# Altium schematic sheet FPGA_BANK.SchDoc(FPGA_BANK)
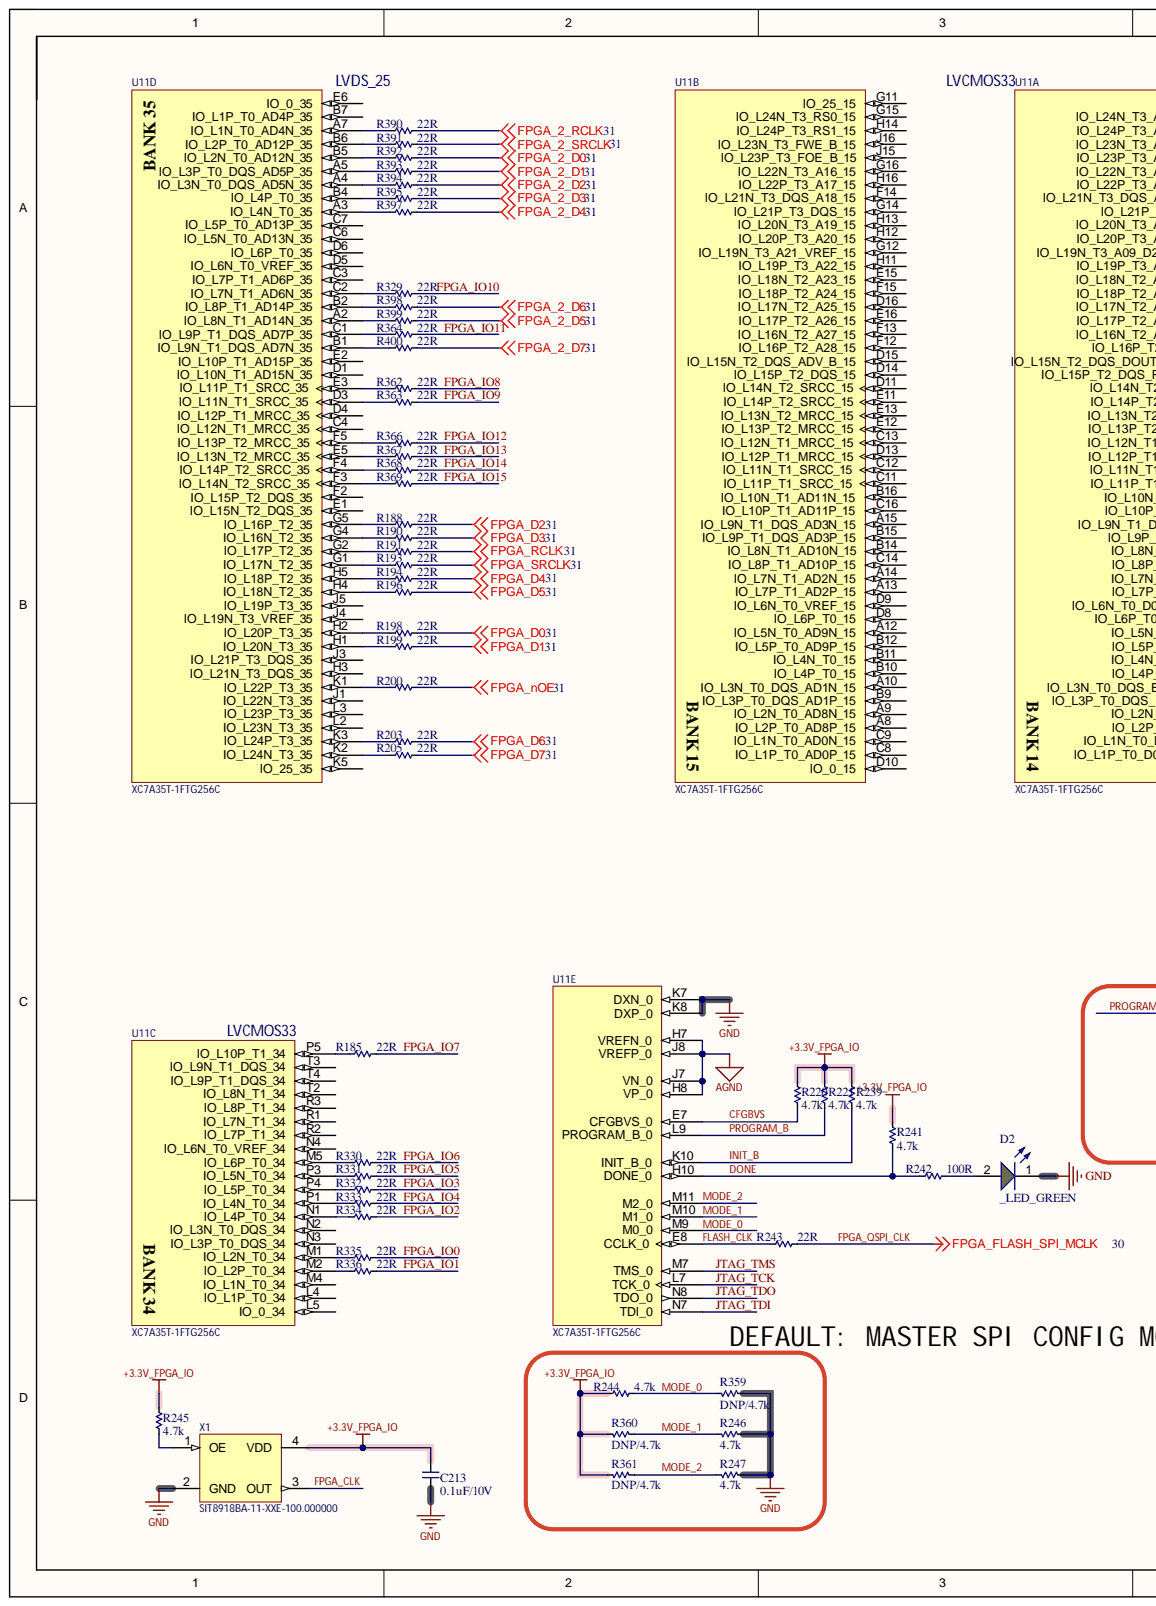
[diagram p0: FPGA_BANK.SchDoc, left]
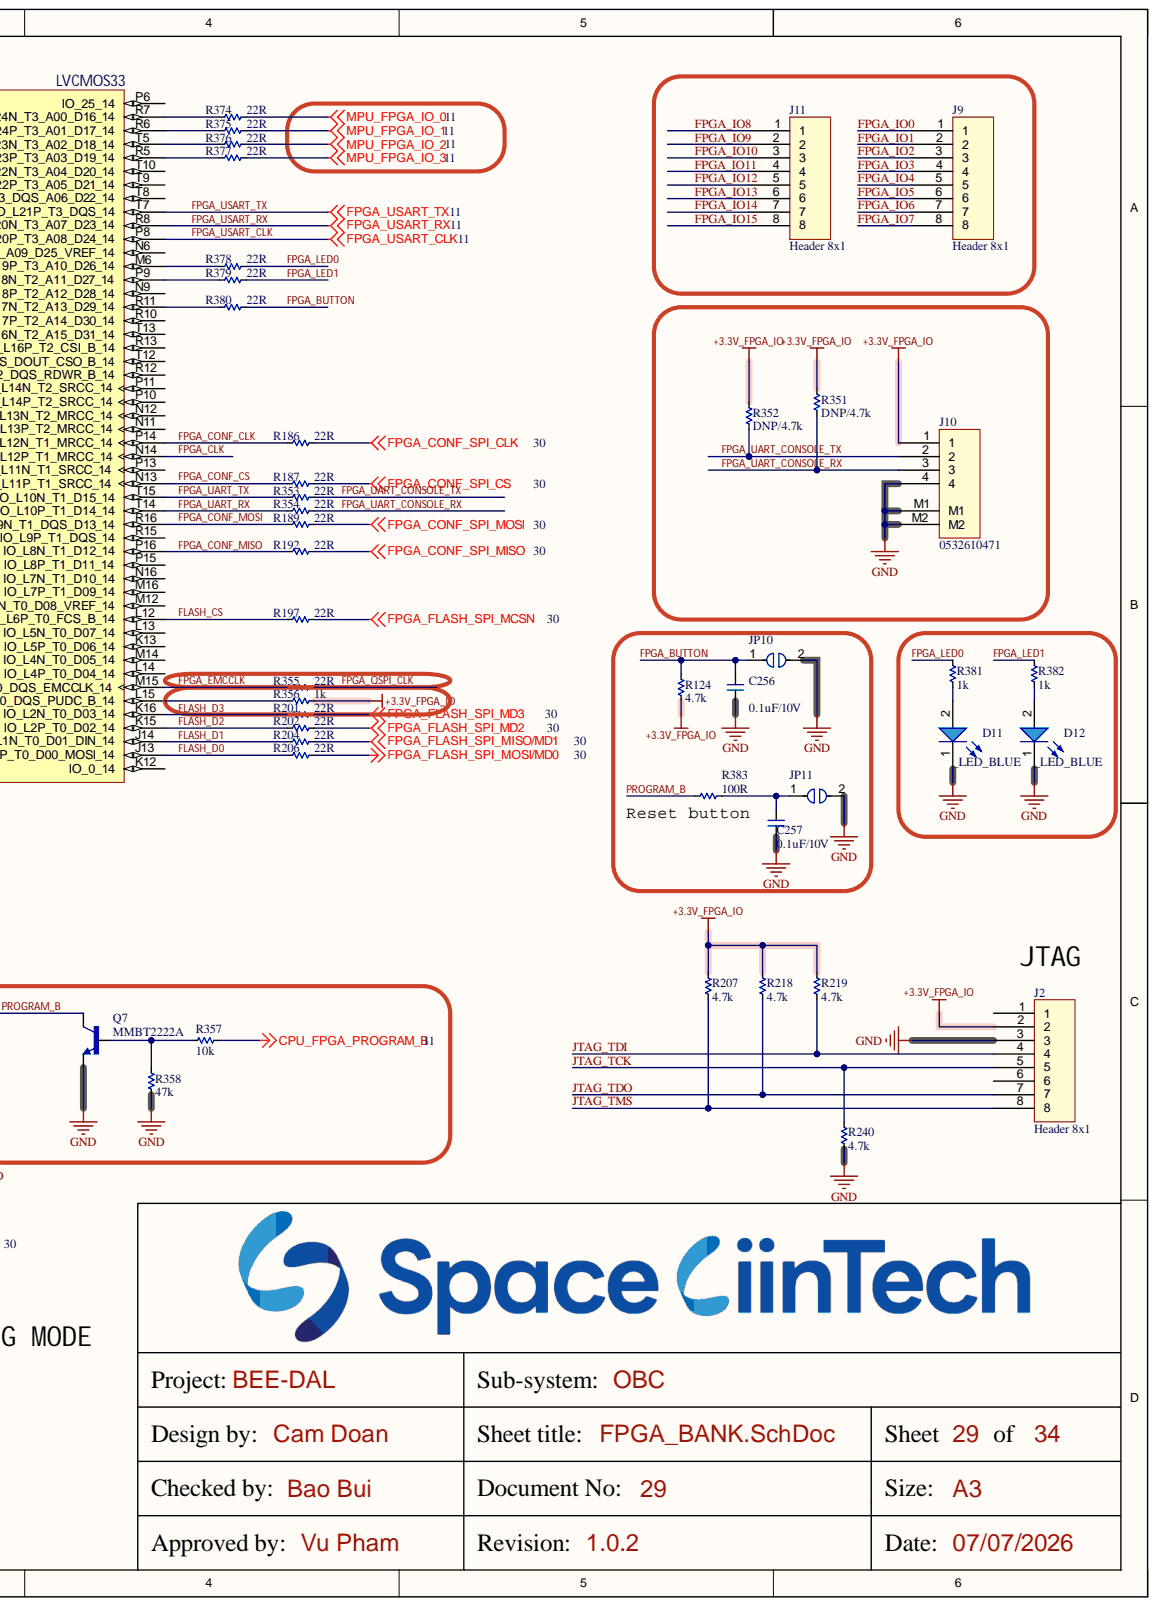
[diagram p1: FPGA_BANK.SchDoc, right]

## Parts
C213  0.1uF/10V  [CAP_C_1005X50_L]
C256  0.1uF/10V  [CAP_C_1005X50_L]
C257  0.1uF/10V  [CAP_C_1005X50_L]
D2  _LED_GREEN  [LED_0603]
D11  _LED_BLUE  [LED_0603]
D12  _LED_BLUE  [LED_0603]
J2  Header 8x1  [Header_254P_8x1]
J9  Header 8x1  [Header_254P_8x1]
J10  0532610471  [MOLEX_0532610471]
J11  Header 8x1  [Header_254P_8x1]
JP10  (no value)  [JUMPER_1608]
JP11  (no value)  [JUMPER_1608]
Q7  MMBT2222A  [SOT95P240X120-3N]
R124  4.7k  [RES_C_1005x30_L]
R185  22R  [RES_C_1005x30_L]
R186  22R  [RES_C_1005x30_L]
R187  22R  [RES_C_1005x30_L]
R188  22R  [RES_C_1005x30_L]
R189  22R  [RES_C_1005x30_L]
R190  22R  [RES_C_1005x30_L]
R191  22R  [RES_C_1005x30_L]
R192  22R  [RES_C_1005x30_L]
R193  22R  [RES_C_1005x30_L]
R194  22R  [RES_C_1005x30_L]
R196  22R  [RES_C_1005x30_L]
R197  22R  [RES_C_1005x30_L]
R198  22R  [RES_C_1005x30_L]
R199  22R  [RES_C_1005x30_L]
R200  22R  [RES_C_1005x30_L]
R201  22R  [RES_C_1005x30_L]
R202  22R  [RES_C_1005x30_L]
R203  22R  [RES_C_1005x30_L]
R204  22R  [RES_C_1005x30_L]
R205  22R  [RES_C_1005x30_L]
R206  22R  [RES_C_1005x30_L]
R207  4.7k  [RES_C_1005x30_L]
R218  4.7k  [RES_C_1005x30_L]
R219  4.7k  [RES_C_1005x30_L]
R220  4.7k  [RES_C_1005x30_L]
R221  4.7k  [RES_C_1005x30_L]
R239  4.7k  [RES_C_1005x30_L]
R240  4.7k  [RES_C_1005x30_L]
R241  4.7k  [RES_C_1005x30_L]
R242  100R  [RES_C_1005x30_L]
R243  22R  [RES_C_1005x30_L]
R244  4.7k  [RES_C_1005x30_L]
R245  4.7k  [RES_C_1005x30_L]
R246  4.7k  [RES_C_1005x30_L]
R247  4.7k  [RES_C_1005x30_L]
R329  22R  [RES_C_1005x30_L]
R330  22R  [RES_C_1005x30_L]
R331  22R  [RES_C_1005x30_L]
R332  22R  [RES_C_1005x30_L]
R333  22R  [RES_C_1005x30_L]
R334  22R  [RES_C_1005x30_L]
R335  22R  [RES_C_1005x30_L]
R336  22R  [RES_C_1005x30_L]
R351  DNP/4.7k  [RES_C_1005x30_L]
R352  DNP/4.7k  [RES_C_1005x30_L]
R353  22R  [RES_C_1005x30_L]
R354  22R  [RES_C_1005x30_L]
R355  22R  [RES_C_1005x30_L]
R356  1k  [RES_C_1005x30_L]
R357  10k  [RES_C_1005x30_L]
R358  47k  [RES_C_1005x30_L]
R359  DNP/4.7k  [RES_C_1005x30_L]
R360  DNP/4.7k  [RES_C_1005x30_L]
R361  DNP/4.7k  [RES_C_1005x30_L]
R362  22R  [RES_C_1005x30_L]
R363  22R  [RES_C_1005x30_L]
R364  22R  [RES_C_1005x30_L]
R366  22R  [RES_C_1005x30_L]
R367  22R  [RES_C_1005x30_L]
R368  22R  [RES_C_1005x30_L]
R369  22R  [RES_C_1005x30_L]
R374  22R  [RES_C_1005x30_L]
R375  22R  [RES_C_1005x30_L]
R376  22R  [RES_C_1005x30_L]
R377  22R  [RES_C_1005x30_L]
R378  22R  [RES_C_1005x30_L]
R379  22R  [RES_C_1005x30_L]
R380  22R  [RES_C_1005x30_L]
R381  1k  [RES_C_1005x30_L]
R382  1k  [RES_C_1005x30_L]
R383  100R  [RES_C_1005x30_L]
R390  22R  [RES_C_1005x30_L]
R391  22R  [RES_C_1005x30_L]
R392  22R  [RES_C_1005x30_L]
R393  22R  [RES_C_1005x30_L]
R394  22R  [RES_C_1005x30_L]
R395  22R  [RES_C_1005x30_L]
R397  22R  [RES_C_1005x30_L]
R398  22R  [RES_C_1005x30_L]
R399  22R  [RES_C_1005x30_L]
R400  22R  [RES_C_1005x30_L]
U11  XC7A35T-1FTG256C  [FTG256]  (parts A,B,C,D,E)
X1  SIT8918BA-11-XXE-100.000000  [CRYSTAL_ABM8G]

## Nets
+3.3V_FPGA_IO: C213.2 J2.2 J10.1 R124.1 R207.2 R218.2 R219.2 R220.2 R221.2 R239.2 R241.2 R244.1 R245.2 R351.1 R352.1 R356.2 R360.2 R361.2 X1.4(VDD)
AGND: U11.H7(VREFN_0) U11.H8(VP_0) U11.J7(VN_0) U11.J8(VREFP_0)
CPU_FPGA_PROGRAM_B: R357.1
FPGA_2_D0: R392.2
FPGA_2_D1: R393.2
FPGA_2_D2: R394.2
FPGA_2_D3: R395.2
FPGA_2_D4: R397.2
FPGA_2_D5: R399.2
FPGA_2_D6: R398.2
FPGA_2_D7: R400.2
FPGA_2_RCLK: R390.2
FPGA_2_SRCLK: R391.2
FPGA_CONF_SPI_CLK: R186.2
FPGA_CONF_SPI_CS: R187.2
FPGA_CONF_SPI_MISO: R192.2
FPGA_CONF_SPI_MOSI: R189.2
FPGA_D0: R198.2
FPGA_D1: R199.2
FPGA_D2: R188.2
FPGA_D3: R190.2
FPGA_D4: R194.2
FPGA_D5: R196.2
FPGA_D6: R203.2
FPGA_D7: R205.2
FPGA_FLASH_SPI_MCSN: R197.2
FPGA_FLASH_SPI_MD2: R202.2
FPGA_FLASH_SPI_MD3: R201.2
FPGA_FLASH_SPI_MISO/MD1: R204.2
FPGA_FLASH_SPI_MOSI/MD0: R206.2
FPGA_nOE: R200.2
FPGA_RCLK: R191.2
FPGA_SRCLK: R193.2
GND: C213.1 C256.1 C257.1 D2.1 D11.1 D12.1 J2.3 J10.4 J10.M1 J10.M2 JP10.2 JP11.2 Q7.2 R240.1 R246.1 R247.1 R358.1 R359.1 U11.K7(DXN_0) U11.K8(DXP_0) X1.2(GND)
MPU_FPGA_IO_0: R374.2
MPU_FPGA_IO_1: R375.2
MPU_FPGA_IO_2: R376.2
MPU_FPGA_IO_3: R377.2
CFGBVS: R220.1 U11.E7(CFGBVS_0)
DONE: R241.1 R242.1 U11.H10(DONE_0)
FLASH_CLK: R243.1 U11.E8(CCLK_0)
FLASH_CS: R197.1 U11.L12(IO_L6P_T0_FCS_B_14)
FLASH_D0: R206.1 U11.J13(IO_L1P_T0_D00_MOSI_14)
FLASH_D1: R204.1 U11.J14(IO_L1N_T0_D01_DIN_14)
FLASH_D2: R202.1 U11.K15(IO_L2P_T0_D02_14)
FLASH_D3: R201.1 U11.K16(IO_L2N_T0_D03_14)
FPGA_BUTTON: C256.2 JP10.1 R124.2 R380.2
FPGA_CLK: U11.N14(IO_L12P_T1_MRCC_14) X1.3(OUT)
FPGA_CONF_CLK: R186.1 U11.P14(IO_L12N_T1_MRCC_14)
FPGA_CONF_CS: R187.1 U11.N13(IO_L11P_T1_SRCC_14)
FPGA_CONF_MISO: R192.1 U11.P16(IO_L8N_T1_D12_14)
FPGA_CONF_MOSI: R189.1 U11.R16(IO_L9N_T1_DQS_D13_14)
FPGA_EMCCLK: R355.1 U11.M15(IO_L3N_T0_DQS_EMCCLK_14)
FPGA_IO0: J9.1 R335.2
FPGA_IO1: J9.2 R336.2
FPGA_IO2: J9.3 R334.2
FPGA_IO3: J9.4 R332.2
FPGA_IO4: J9.5 R333.2
FPGA_IO5: J9.6 R331.2
FPGA_IO6: J9.7 R330.2
FPGA_IO7: J9.8 R185.2
FPGA_IO8: J11.1 R362.2
FPGA_IO9: J11.2 R363.2
FPGA_IO10: J11.3 R329.2
FPGA_IO11: J11.4 R364.2
FPGA_IO12: J11.5 R366.2
FPGA_IO13: J11.6 R367.2
FPGA_IO14: J11.7 R368.2
FPGA_IO15: J11.8 R369.2
FPGA_LED0: R378.2 R381.2
FPGA_LED1: R379.2 R382.2
FPGA_QSPI_CLK: R243.2 R355.2
FPGA_UART_CONSOLE_RX: J10.3 R351.2 R354.2
FPGA_UART_CONSOLE_TX: J10.2 R352.2 R353.2
FPGA_UART_RX: R354.1 U11.T14(IO_L10P_T1_D14_14)
FPGA_UART_TX: R353.1 U11.T15(IO_L10N_T1_D15_14)
FPGA_USART_CLK: U11.P8(IO_L20P_T3_A08_D24_14)
FPGA_USART_RX: U11.R8(IO_L20N_T3_A07_D23_14)
FPGA_USART_TX: U11.T7(IO_L21P_T3_DQS_14)
INIT_B: R239.1 U11.K10(INIT_B_0)
JTAG_TCK: J2.5 R240.2 U11.L7(TCK_0)
JTAG_TDI: J2.4 R219.1 U11.N7(TDI_0)
JTAG_TDO: J2.7 R218.1 U11.N8(TDO_0)
JTAG_TMS: J2.8 R207.1 U11.M7(TMS_0)
MODE_0: R244.2 R359.2 U11.M9(M0_0)
MODE_1: R246.2 R360.1 U11.M10(M1_0)
MODE_2: R247.2 R361.1 U11.M11(M2_0)
PROGRAM_B: Q7.3 R221.1 R383.1 U11.L9(PROGRAM_B_0)
NetC257_2: C257.2 JP11.1 R383.2
NetD2_2: D2.2 R242.2
NetD11_2: D11.2 R381.1
NetD12_2: D12.2 R382.1
NetQ7_1: Q7.1 R357.2 R358.2
NetR185_1: R185.1 U11.P5(IO_L10P_T1_34)
NetR188_1: R188.1 U11.G5(IO_L16P_T2_35)
NetR190_1: R190.1 U11.G4(IO_L16N_T2_35)
NetR191_1: R191.1 U11.G2(IO_L17P_T2_35)
NetR193_1: R193.1 U11.G1(IO_L17N_T2_35)
NetR194_1: R194.1 U11.H5(IO_L18P_T2_35)
NetR196_1: R196.1 U11.H4(IO_L18N_T2_35)
NetR198_1: R198.1 U11.H2(IO_L20P_T3_35)
NetR199_1: R199.1 U11.H1(IO_L20N_T3_35)
NetR200_1: R200.1 U11.K1(IO_L22P_T3_35)
NetR203_1: R203.1 U11.K3(IO_L24P_T3_35)
NetR205_1: R205.1 U11.K2(IO_L24N_T3_35)
NetR245_1: R245.1 X1.1(OE)
NetR329_1: R329.1 U11.C2(IO_L7N_T1_AD6N_35)
NetR330_1: R330.1 U11.M5(IO_L6P_T0_34)
NetR331_1: R331.1 U11.P3(IO_L5N_T0_34)
NetR332_1: R332.1 U11.P4(IO_L5P_T0_34)
NetR333_1: R333.1 U11.P1(IO_L4N_T0_34)
NetR334_1: R334.1 U11.N1(IO_L4P_T0_34)
NetR335_1: R335.1 U11.M1(IO_L2N_T0_34)
NetR336_1: R336.1 U11.M2(IO_L2P_T0_34)
NetR356_1: R356.1 U11.L15(IO_L3P_T0_DQS_PUDC_B_14)
NetR362_1: R362.1 U11.E3(IO_L11P_T1_SRCC_35)
NetR363_1: R363.1 U11.D3(IO_L11N_T1_SRCC_35)
NetR364_1: R364.1 U11.C1(IO_L9P_T1_DQS_AD7P_35)
NetR366_1: R366.1 U11.F5(IO_L13P_T2_MRCC_35)
NetR367_1: R367.1 U11.E5(IO_L13N_T2_MRCC_35)
NetR368_1: R368.1 U11.F4(IO_L14P_T2_SRCC_35)
NetR369_1: R369.1 U11.F3(IO_L14N_T2_SRCC_35)
NetR374_1: R374.1 U11.R7(IO_L24N_T3_A00_D16_14)
NetR375_1: R375.1 U11.R6(IO_L24P_T3_A01_D17_14)
NetR376_1: R376.1 U11.T5(IO_L23N_T3_A02_D18_14)
NetR377_1: R377.1 U11.R5(IO_L23P_T3_A03_D19_14)
NetR378_1: R378.1 U11.M6(IO_L19P_T3_A10_D26_14)
NetR379_1: R379.1 U11.P9(IO_L18N_T2_A11_D27_14)
NetR380_1: R380.1 U11.R11(IO_L17N_T2_A13_D29_14)
NetR390_1: R390.1 U11.A7(IO_L1N_T0_AD4N_35)
NetR391_1: R391.1 U11.B6(IO_L2P_T0_AD12P_35)
NetR392_1: R392.1 U11.B5(IO_L2N_T0_AD12N_35)
NetR393_1: R393.1 U11.A5(IO_L3P_T0_DQS_AD5P_35)
NetR394_1: R394.1 U11.A4(IO_L3N_T0_DQS_AD5N_35)
NetR395_1: R395.1 U11.B4(IO_L4P_T0_35)
NetR397_1: R397.1 U11.A3(IO_L4N_T0_35)
NetR398_1: R398.1 U11.B2(IO_L8P_T1_AD14P_35)
NetR399_1: R399.1 U11.A2(IO_L8N_T1_AD14N_35)
NetR400_1: R400.1 U11.B1(IO_L9N_T1_DQS_AD7N_35)

Not connected: J2 1 6; U11 A8(IO_L2P_T0_AD8P_15) A9(IO_L2N_T0_AD8N_15) A10(IO_L3N_T0_DQS_AD1N_15) A12(IO_L5N_T0_AD9N_15) A13(IO_L7P_T1_AD2P_15) A14(IO_L7N_T1_AD2N_15) A15(IO_L9N_T1_DQS_AD3N_15) B7(IO_L1P_T0_AD4P_35) B9(IO_L3P_T0_DQS_AD1P_15) B10(IO_L4P_T0_15) B11(IO_L4N_T0_15) B12(IO_L5P_T0_AD9P_15) B14(IO_L8N_T1_AD10N_15) B15(IO_L9P_T1_DQS_AD3P_15) B16(IO_L10N_T1_AD11N_15) C3(IO_L7P_T1_AD6P_35) C4(IO_L12N_T1_MRCC_35) C6(IO_L5N_T0_AD13N_35) C7(IO_L5P_T0_AD13P_35) C8(IO_L1P_T0_AD0P_15) C9(IO_L1N_T0_AD0N_15) C11(IO_L11P_T1_SRCC_15) C12(IO_L11N_T1_SRCC_15) C13(IO_L12N_T1_MRCC_15) C14(IO_L8P_T1_AD10P_15) C16(IO_L10P_T1_AD11P_15) D1(IO_L10N_T1_AD15N_35) D4(IO_L12P_T1_MRCC_35) D5(IO_L6N_T0_VREF_35) D6(IO_L6P_T0_35) D8(IO_L6P_T0_15) D9(IO_L6N_T0_VREF_15) D10(IO_0_15) D11(IO_L14N_T2_SRCC_15) D13(IO_L12P_T1_MRCC_15) D14(IO_L15P_T2_DQS_15) D15(IO_L15N_T2_DQS_ADV_B_15) D16(IO_L17N_T2_A25_15) E1(IO_L15N_T2_DQS_35) E2(IO_L10P_T1_AD15P_35) E6(IO_0_35) E11(IO_L14P_T2_SRCC_15) E12(IO_L13P_T2_MRCC_15) E13(IO_L13N_T2_MRCC_15) E15(IO_L18N_T2_A23_15) E16(IO_L17P_T2_A26_15) F2(IO_L15P_T2_DQS_35) F12(IO_L16P_T2_A28_15) F13(IO_L16N_T2_A27_15) F14(IO_L21N_T3_DQS_A18_15) F15(IO_L18P_T2_A24_15) G11(IO_25_15) G12(IO_L19N_T3_A21_VREF_15) G14(IO_L21P_T3_DQS_15) G15(IO_L24N_T3_RS0_15) G16(IO_L22N_T3_A16_15) H3(IO_L21N_T3_DQS_35) H11(IO_L19P_T3_A22_15) H12(IO_L20P_T3_A20_15) H13(IO_L20N_T3_A19_15) H14(IO_L24P_T3_RS1_15) H16(IO_L22P_T3_A17_15) J1(IO_L22N_T3_35) J3(IO_L21P_T3_DQS_35) J4(IO_L19N_T3_VREF_35) J5(IO_L19P_T3_35) J15(IO_L23P_T3_FOE_B_15) J16(IO_L23N_T3_FWE_B_15) K5(IO_25_35) K12(IO_0_14) K13(IO_L5P_T0_D06_14) L2(IO_L23N_T3_35) L3(IO_L23P_T3_35) L4(IO_L1P_T0_34) L5(IO_0_34) L13(IO_L5N_T0_D07_14) L14(IO_L4P_T0_D04_14) M4(IO_L1N_T0_34) M12(IO_L6N_T0_D08_VREF_14) M14(IO_L4N_T0_D05_14) M16(IO_L7P_T1_D09_14) N2(IO_L3N_T0_DQS_34) N3(IO_L3P_T0_DQS_34) N4(IO_L6N_T0_VREF_34) N6(IO_L19N_T3_A09_D25_VREF_14) N9(IO_L18P_T2_A12_D28_14) N11(IO_L13P_T2_MRCC_14) N12(IO_L13N_T2_MRCC_14) N16(IO_L7N_T1_D10_14) P6(IO_25_14) P10(IO_L14P_T2_SRCC_14) P11(IO_L14N_T2_SRCC_14) P13(IO_L11N_T1_SRCC_14) P15(IO_L8P_T1_D11_14) R1(IO_L7N_T1_34) R2(IO_L7P_T1_34) R3(IO_L8P_T1_34) R10(IO_L17P_T2_A14_D30_14) R12(IO_L15P_T2_DQS_RDWR_B_14) R13(IO_L16P_T2_CSI_B_14) R15(IO_L9P_T1_DQS_14) T2(IO_L8N_T1_34) T3(IO_L9N_T1_DQS_34) T4(IO_L9P_T1_DQS_34) T8(IO_L21N_T3_DQS_A06_D22_14) T9(IO_L22P_T3_A05_D21_14) T10(IO_L22N_T3_A04_D20_14) T12(IO_L15N_T2_DQS_DOUT_CSO_B_14) T13(IO_L16N_T2_A15_D31_14)

## Library data of the parts
C213 C256 C257 = _CAP_C_2: CAP; Component Kind: Standard; Library Name: LABLIB.SchLib
D2 = LED_GREEN: LED GREEN CLEAR 0603 SMD; Component Kind: Standard; Library Name: IFBschelib.SchLib
D11 D12 = LED_BLUE: LED BLUE CLEAR 0603 SMD; Component Kind: Standard; Library Name: IFBschelib.SchLib
J10 = Header 4x1_2M: Component Kind: Standard; Library Name: IFBschelib.SchLib
JP10 JP11 = JUMPER_1_1: Component Kind: Standard; Library Name: LABLIB.SchLib
Q7 = BJT_NPN_BEC: MF: Diotec Semiconductor; Check_prices: https://www.snapeda.com/parts/MMBT2222A/Diotec/view-part/?ref=eda; Component Kind: Standard; MP: MMBT2222A; Package: SOT-23 Diotec; SnapEDA_Link: https://www.snapeda.com/parts/MMBT2222A/Diotec/view-part/?ref=snap; Library Name: LABLIB.SchLib
R124 R185-R194 R196-R207 R218-R221 R239-R247 R329-R336 R351-R364 R366-R369 R374-R383 R390-R395 R397-R400 = _RES_B_2: RES; Component Kind: Standard
  R124 R207 R218-R221 R239-R241 R351 R352 R358: Library Name: IFBschelib.SchLib
  R185-R194 R196-R206 R242-R247 R329-R336 R353-R357 R359-R364 R366-R369 R374-R383 R390-R395 R397-R400: Library Name: LABLIB.SchLib
U11 = CMP-0383-00028-1: Artix-7 FPGA, 170 User I/Os, 0 GTP, 256-Ball BGA, Speed Grade 1, Commercial Grade, Pb-Free; Manufacturer: Xilinx; Available User I/O: 170; CMTs: 5; GTP: 0; Logic Cells: 33280; Speed Grades: 1; Total Block RAM: 1800 Kb; Component Kind: Standard; ComponentLink1Description: Manufacturer URL; ComponentLink1URL: http://www.xilinx.com/; ComponentLink2Description: Datasheet; ComponentLink2URL: http://www.xilinx.com/support/documentation/data_sheets/ds180_7Series_Overview.pdf; ComponentLink3Description: Pinout; ComponentLink3URL: http://www.xilinx.com/support/documentation/user_guides/ug475_7Series_Pkg_Pinout.pdf; ComponentLink4Description: Package Specification; ComponentLink4URL: http://www.xilinx.com/support/documentation/user_guides/ug475_7Series_Pkg_Pinout.pdf; DatasheetVersion: Rev. 1.15; Library Name: Altium Content Vault; Maximum Distributed RAM: 400 Kb; Mounting Technology: Surface Mount; Operating Temperature Range: Commercial; PackageDescription: 256-Ball Wire-Bond Fine-Pitch Thin BGA, Body 17 x 17 mm, Pitch 1 mm; PackageReference: FTG-256; PackageVersion: Rev. 1.11; PartNumber: XC7A35T-1FTG256C
X1 = SIT8008AI-11-XXE-100.000000: Oscillator MEMS 100MHz ±20ppm (Stability) 15pF HCMOS/LVCMOS 55% 2.5V/2.8V/3V/3.3V Medical 4-Pin QFN SMD T/R; Manufacturer: SiTime; Manufacturer Part Number: SIT8008AI-11-XXE-100.000000E; Max Supply Current: 4.5mA; Case/Package: SMD/SMT; Category: Oscillators; Component Kind: Standard; ComponentLink1Description: https://media.digikey.com/pdf/Data%20Sheets/SiTime%20PDFs/New_Rev_FAQ.pdf?src-supplier=Digi-Key; ComponentLink1URL: https://media.digikey.com/pdf/Data%20Sheets/SiTime%20PDFs/New_Rev_FAQ.pdf?src-supplier=Digi-Key; Frequency: 100MHz; Frequency Stability: 20ppm; Max Operating Temperature: 85°C; Min Operating Temperature: -40°C; Mount: Surface Mount; Packaging: Tape and Reel; Pricing 1: 1000=0.93600, 2000=0.91104, 4000=0.88608, 6000=0.86112, 8000=0.83616 (USD); RoHS: Yes; RoHS Compliant: Yes; Supplier 1: Avnet; Supplier Part Number 1: SIT8008AI-11-XXE-100.000000E; Library Name: Virtex.SchLib
J2 J9 J11 = Header 8x1: Component Kind: Standard; Library Name: LABLIB.SchLib
